# Altium schematic sheet LATCHING_CIRCUITS.SchDoc(LATCHING_CIRCUITS)
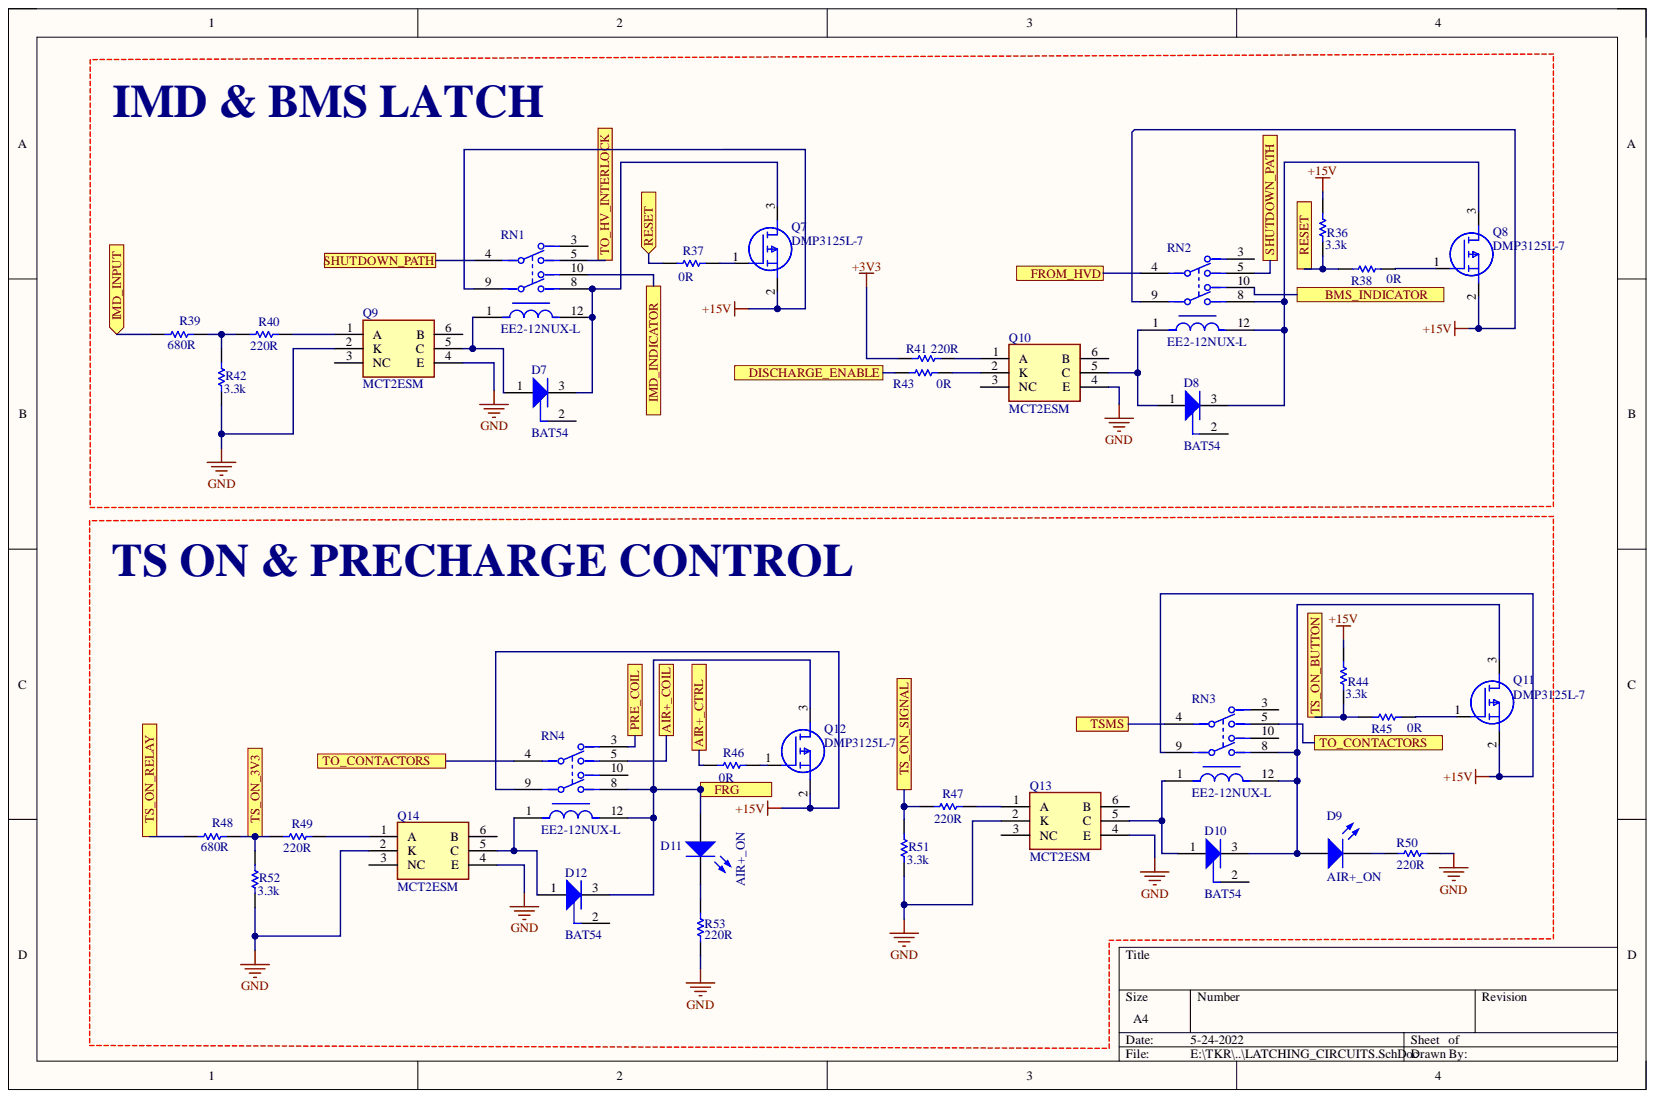
[diagram p0: LATCHING_CIRCUITS.SchDoc]

## Parts
D7  BAT54  [INF-SOT23_N]
D8  BAT54  [INF-SOT23_N]
D9  AIR+_ON  [3.2X1.6X1.1]
D10  BAT54  [INF-SOT23_N]
D11  AIR+_ON  [3.2X1.6X1.1]
D12  BAT54  [INF-SOT23_N]
Q7  DMP3125L-7  [SOT96P240X110-3N]
Q8  DMP3125L-7  [SOT96P240X110-3N]
Q9  MCT2ESM  [SOT254P916X480-6N]
Q10  MCT2ESM  [SOT254P916X480-6N]
Q11  DMP3125L-7  [SOT96P240X110-3N]
Q12  DMP3125L-7  [SOT96P240X110-3N]
Q13  MCT2ESM  [SOT254P916X480-6N]
Q14  MCT2ESM  [SOT254P916X480-6N]
R36  3.3k  [RESC3216X60X45NL10T20 - duplicate]
R37  0R  [RESC3216X60X45NL10T20 - duplicate]
R38  0R  [RESC3216X60X45NL10T20 - duplicate]
R39  680R  [RESC3216X60X45NL10T20 - duplicate]
R40  220R  [RESC3216X60X45NL10T20 - duplicate]
R41  220R  [RESC3216X60X45NL10T20 - duplicate]
R42  3.3k  [RESC3216X60X45NL10T20 - duplicate]
R43  0R  [RESC3216X60X45NL10T20 - duplicate]
R44  3.3k  [RESC3216X60X45NL10T20 - duplicate]
R45  0R  [RESC3216X60X45NL10T20 - duplicate]
R46  0R  [RESC3216X60X45NL10T20 - duplicate]
R47  220R  [RESC3216X60X45NL10T20 - duplicate]
R48  680R  [RESC3216X60X45NL10T20 - duplicate]
R49  220R  [RESC3216X60X45NL10T20 - duplicate]
R50  220R  [RESC3216X60X45NL10T20 - duplicate]
R51  3.3k  [RESC3216X60X45NL10T20 - duplicate]
R52  3.3k  [RESC3216X60X45NL10T20 - duplicate]
R53  220R  [RESC3216X60X45NL10T20 - duplicate]
RN1  EE2-12NUX-L  [EE2-12NUX-L]
RN2  EE2-12NUX-L  [EE2-12NUX-L]
RN3  EE2-12NUX-L  [EE2-12NUX-L]
RN4  EE2-12NUX-L  [EE2-12NUX-L]

## Ports
AIR+_COIL, AIR+_CTRL, BMS_INDICATOR, DISCHARGE_ENABLE, FRG, FROM_HVD, IMD_INDICATOR, IMD_INPUT, PRE_COIL, RESET, SHUTDOWN_PATH, TO_CONTACTORS, TO_HV_INTERLOCK, TS_ON_3V3, TS_ON_BUTTON, TS_ON_RELAY, TS_ON_SIGNAL, TSMS

## Nets
+3V3: R41.2
+15V: Q7.2 Q8.2 Q11.2 Q12.2 R36.2 R44.2 RN1.9 RN2.9 RN3.9 RN4.9
GND: Q9.2(K) Q9.4(E) Q10.4(E) Q13.2(K) Q13.4(E) Q14.2(K) Q14.4(E) R42.1 R50.1 R51.1 R52.1 R53.1
AIR+_COIL: RN4.5 ; port AIR+_COIL
AIR+_CTRL: R46.2 ; port AIR+_CTRL
BMS_INDICATOR: RN2.10 ; port BMS_INDICATOR
DISCHARGE_ENABLE: R43.2 ; port DISCHARGE_ENABLE
FRG: D11.1 D12.3 Q12.3 RN4.8 RN4.12 ; port FRG
FROM_HVD: RN2.4 ; port FROM_HVD
IMD_INDICATOR: RN1.10 ; port IMD_INDICATOR
IMD_INPUT: R39.2 ; port IMD_INPUT
PRE_COIL: RN4.3 ; port PRE_COIL
RESET: R36.1 R37.2 R38.2 ; port RESET
SHUTDOWN_PATH: RN1.4 RN2.5 ; port SHUTDOWN_PATH
TO_CONTACTORS: RN3.5 RN4.4 ; port TO_CONTACTORS
TO_HV_INTERLOCK: RN1.5 ; port TO_HV_INTERLOCK
TS_ON_3V3: R48.1 R49.2 R52.2 ; port TS_ON_3V3
TS_ON_BUTTON: R44.1 R45.2 ; port TS_ON_BUTTON
TS_ON_RELAY: R48.2 ; port TS_ON_RELAY
TS_ON_SIGNAL: R47.2 R51.2 ; port TS_ON_SIGNAL
TSMS: RN3.4 ; port TSMS
NetD7_1: D7.1 Q9.5(C) RN1.1
NetD7_3: D7.3 Q7.3 RN1.8 RN1.12
NetD8_1: D8.1 Q10.5(C) RN2.1
NetD8_3: D8.3 Q8.3 RN2.8 RN2.12
NetD9_1: D9.1 D10.3 Q11.3 RN3.8 RN3.12
NetD9_2: D9.2 R50.2
NetD10_1: D10.1 Q13.5(C) RN3.1
NetD11_2: D11.2 R53.2
NetD12_1: D12.1 Q14.5(C) RN4.1
NetQ7_1: Q7.1 R37.1
NetQ8_1: Q8.1 R38.1
NetQ9_1: Q9.1(A) R40.1
NetQ10_1: Q10.1(A) R41.1
NetQ10_2: Q10.2(K) R43.1
NetQ11_1: Q11.1 R45.1
NetQ12_1: Q12.1 R46.1
NetQ13_1: Q13.1(A) R47.1
NetQ14_1: Q14.1(A) R49.1
NetR39_1: R39.1 R40.2 R42.2

Not connected: D7 2; D8 2; D10 2; D12 2; Q9 3(NC) 6(B); Q10 3(NC) 6(B); Q13 3(NC) 6(B); Q14 3(NC) 6(B); RN1 3; RN2 3; RN3 3 10; RN4 10

## Library data of the parts
D7 D8 D10 D12 = CMP-1182-00010-1: Silicon Schottky Diode, SOT23, Reel, Green; Manufacturer: Infineon; Configuration: Single; CT (max): 10Â pF; Green: TRUE; IF (max): 200Â mA; VF (max): 800Â mV; VR (max): 30Â V; Component Kind: Standard; ComponentLink1Description: Manufacturer URL; ComponentLink1URL: http://www.infineon.com/; ComponentLink2Description: Datasheet; ComponentLink2URL: http://www.infineon.com/dgdl/bat54series.pdf?folderId=db3a30431400ef68011425f1ca2505e3&fileId=db3a304314dca3890115190b74210ea6; ComponentLink3Description: Package Specification; ComponentLink3URL: http://www.infineon.com/dgdl/SOT23-Package_Overview.pdf?folderId=db3a30431936bc4b0119532290053c66&fileId=db3a30431936bc4b0119533468123c85; Library Name: Schlib1.SchLib; Mounting Technology: Surface Mount; PackageDescription: 3-Pin Small Outline Transistor Package, 1.3 x 2.9 mm Body, 0.95 mm Pitch; PackageReference: SOT23; PackageVersion: Apr-08; Packing: Reel; PartNumber: BAT54
D9 D11 = LED2: Typical RED, GREEN, YELLOW, AMBER GaAs LED; Component Kind: Standard; LatestRevisionDate: 17-Jul-2002; LatestRevisionNote: Re-released for DXP Platform.; Library Name: Miscellaneous Devices.IntLib; PackageDescription: SM LED; 2 Flat Leads; PackageReference: 3.2X1.6X1.1; PackageVersion: Feb-2002; Published: 8-Jun-2000; Simulation: LED2; Publisher: Altium Limited
Q7 Q8 Q11 Q12 = DMP3125L-7: MOSFET (P-Channel); Manufacturer_Name: Diodes Inc.; Arrow Part Number: DMP3125L-7; Arrow Price/Stock: https://www.arrow.com/en/products/dmp3125l-7/diodes-incorporated; Component Kind: Standard; Datasheet Link: http://uk.rs-online.com/web/p/products/1826917; Height: 1.1mm; Manufacturer_Part_Number: DMP3125L-7; Mouser Part Number: 621-DMP3125L-7; Mouser Price/Stock: https://www.mouser.co.uk/ProductDetail/Diodes-Incorporated/DMP3125L-7?qs=W0yvOO0ixfH8ma8MdHfXQA%3D%3D
Q9 Q10 Q13 Q14 = MCT2ESM: Phototransistor; Manufacturer_Name: ON Semiconductor; Arrow Part Number: MCT2ESM; Arrow Price/Stock: https://www.arrow.com/en/products/mct2esm/on-semiconductor; Component Kind: Standard; Datasheet Link: https://www.onsemi.com/pub/Collateral/MCT2EM-D.PDF; Height: 4.8mm; Library Name: SamacSys.SchLib; Manufacturer_Part_Number: MCT2ESM; Mouser Part Number: 512-MCT2ESM; Mouser Price/Stock: https://www.mouser.co.uk/ProductDetail/ON-Semiconductor-Fairchild/MCT2ESM/?qs=nW0pe8qlIZjLnc6UyUzYTw%3D%3D
R36-R53 = CMP-2003-02213-1: Manufacturer: Vishay Dale; Part Number: CRCW120647K0FKEA; Component Kind: Standard
  R36-R49 R51 R52: Library Name: Schlib1.SchLib
  R50 R53: Library Name: Regular_used_components_2D_Symbol.SchLib
RN1-RN4 = EE2-12NUX-L: Component Kind: Standard; Library Name: Schlib1.SchLib
